# Altium schematic sheet Board.SchDoc
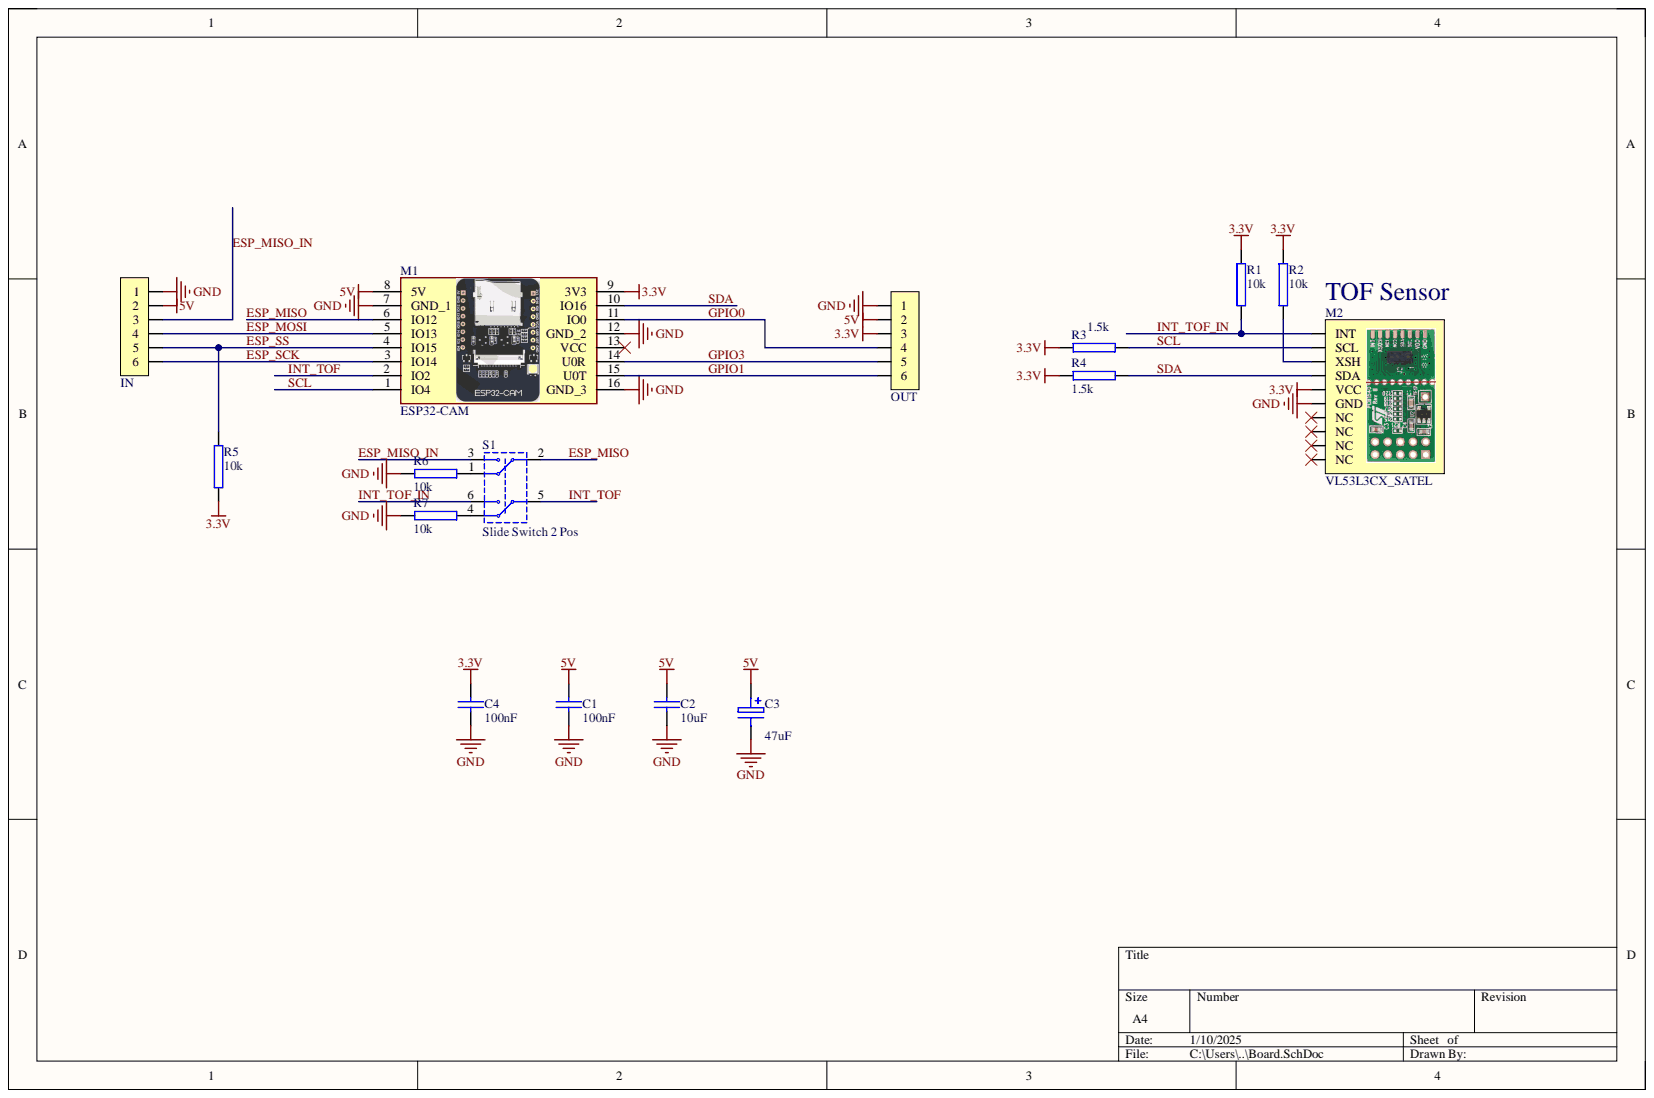
[diagram p0: Board.SchDoc]

## Parts
C1  value 100nF  [0603]  (hidden comment: C 100nF 0603)
C2  value 10uF  [0603]  (hidden comment: C 10uF 0603)
C3  value 47uF  [HA1C470WR]  (hidden comment: C 47uF ALUM)
C4  value 100nF  [0603]  (hidden comment: C 100nF 0603)
IN  6Pin_Male  [Header1x6_254]  (value not drawn)
M1  ESP32-CAM  [ESP32-CAM]
M2  VL53L3CX_SATEL  [VL53L3CX_SATEL]
OUT  6Pin_Male  [Header1x6_254]  (value not drawn)
R1  value 10k  [0805]  (hidden comment: R 10K 0805)
R2  value 10k  [0805]  (hidden comment: R 10K 0805)
R3  value 1.5k  [0805]  (hidden comment: R 1K5 0805)
R4  value 1.5k  [0805]  (hidden comment: R 1K5 0805)
R5  value 10k  [0805]  (hidden comment: R 10K 0805)
R6  value 10k  [0805]  (hidden comment: R 10K 0805)
R7  value 10k  [0805]  (hidden comment: R 10K 0805)
S1  Slide Switch 2 Pos  [Slide_Switch_6Pin]

## Nets
3.3V: C4.1 M1.9(3V3) M2.5(VCC) OUT.3 R1.2 R2.2 R3.1 R4.1 R5.1
5V: C1.1 C2.1 C3.1 IN.2 M1.8(5V) OUT.2
GND: C1.2 C2.2 C3.2 C4.2 IN.1 M1.7(GND_1) M1.12(GND_2) M1.16(GND_3) M2.6(GND) OUT.1 R6.2 R7.2
ESP_MISO: M1.6(IO12) S1.2
ESP_MISO_IN: IN.3 S1.3
ESP_MOSI: IN.4 M1.5(IO13)
ESP_SCK: IN.6 M1.3(IO14)
ESP_SS: IN.5 M1.4(IO15) R5.2
GPIO0: M1.11(IO0) OUT.4
GPIO1: M1.15(U0T) OUT.6
GPIO3: M1.14(U0R) OUT.5
INT_TOF: M1.2(IO2) S1.5
INT_TOF_IN: M2.1(INT) R1.1 S1.6
SCL: M1.1(IO4) M2.2(SCL) R3.2
SDA: M1.10(IO16) M2.4(SDA) R4.2
NetM2_3: M2.3(XSH) R2.1
NetR6_1: R6.1 S1.1
NetR7_1: R7.1 S1.4

Not connected: M1 13(VCC); M2 7(NC) 8(NC) 9(NC) 10(NC)

## Library data of the parts
C1 C4 = C_100nF_0603: Database Table Name: Capacitors; TempKoeff: Y5V; Tolerance: -20%/+80%; Voltage: 50V; Component Kind: Standard; Part ID: C_100nF_0603; Price 1pc (CHF): 0.1; Signal Integrity: SignalIntegrity; Simulation: Model Name; Supplier 1: Digi-Key; Supplier Part Number 1: 1276-1012-1-ND; Library Name: FHGR_Altium_database.DbLib
C2 = C_10uF_0603: Database Table Name: Capacitors; TempKoeff: X5R; Tolerance: 10%; Voltage: 16V; Component Kind: Standard; Part ID: C_10uF_0603; Price 1pc (CHF): 0.40; Signal Integrity: SignalIntegrity; Simulation: Model Name; Supplier 1: Digi-Key; Supplier Part Number 1: 490-12317-1-ND; Library Name: FHGR_Altium_database.DbLib
C3 = C_47uF_ALUM: Database Table Name: Capacitors; Tolerance: 20%; Voltage: 16V; Component Kind: Standard; Part ID: C_47uF_ALUM; Price 1pc (CHF): 0.08; Signal Integrity: Model Name; Simulation: Model Name; Supplier 1: Digi-Key; Supplier Part Number 1: PCE4190TR-ND; Library Name: FHGR_Altium_database.DbLib
IN OUT = ArduinoConnector_6: Database Table Name: Connectors; Component Kind: Standard; ComponentLink1Description: Datasheet; ComponentLink1URL: https://katalog.we-online.de/em/datasheet/6130xx11121.pdf; Part ID: ArduinoConnector_6; Price 1pc (CHF): 0.32; Supplier 1: Digi-Key; Supplier Part Number 1: 732-5319-ND; Library Name: FHGR_Altium_database.DbLib
M1 = CMP-000000-6: ESP32-CAM Combo Wireless Module Development Board; Component Kind: Standard; Library Name: FH Graubünden
M2 = CMP-037-000019-1: TOF Sensor; Buchse: 732-2865-ND; Stecker: 732-2672-ND; Component Kind: Standard; ComponentLink1Description: Datasheet; Part ID: VL53L3CX_SATEL; Price 1pc (CHF): 18.15; ComponentLink1URL: file:///C:/Users/CATREG~1/AppData/Local/Temp/vl53l3cx-satel-1.pdf; Library Name: FH Graubünden
R1 R2 R5-R7 = R_10k_0805: Database Table Name: Resistors; Power Dissipation: 125mW; Tolerance: 1%; Component Kind: Standard; Part ID: R_10k_0805; Price 10pcs (CHF): 0.021; Signal Integrity: SignalIntegrity; Simulation: Model Name; Supplier 1: Digi-Key; Supplier Part Number 1: 311-10.0KCRCT-ND; Library Name: FHGR_Altium_database.DbLib
R3 R4 = R_1k5_0805: Database Table Name: Resistors; Power Dissipation: 250mW; Tolerance: 1%; Component Kind: Standard; Part ID: R_1k5_0805; Price 10pcs (CHF): 0.072; Signal Integrity: SignalIntegrity; Simulation: Model Name; Supplier 1: Digi-Key; Supplier Part Number 1: RNCP0805FTD1K50CT-ND; Library Name: FHGR_Altium_database.DbLib
S1 = Slide_Switch_2Pos: Current: 300mA; Database Table Name: Electromechanics; Voltage: 6V; Component Kind: Standard; ComponentLink1Description: Datasheet; ComponentLink1URL: https://www.ckswitches.com/media/1422/js.pdf; Dimension: 9mmx7.5mmx5.5mm; Part ID: Slide_Switch_2Pos; Price 1pc (CHF): 0.48; Supplier 1: Digi-Key; Supplier Part Number 1: 401-2002-1-ND; Library Name: FHGR_Altium_database.DbLib
